# Altium schematic sheet DC_BUS.SchDoc
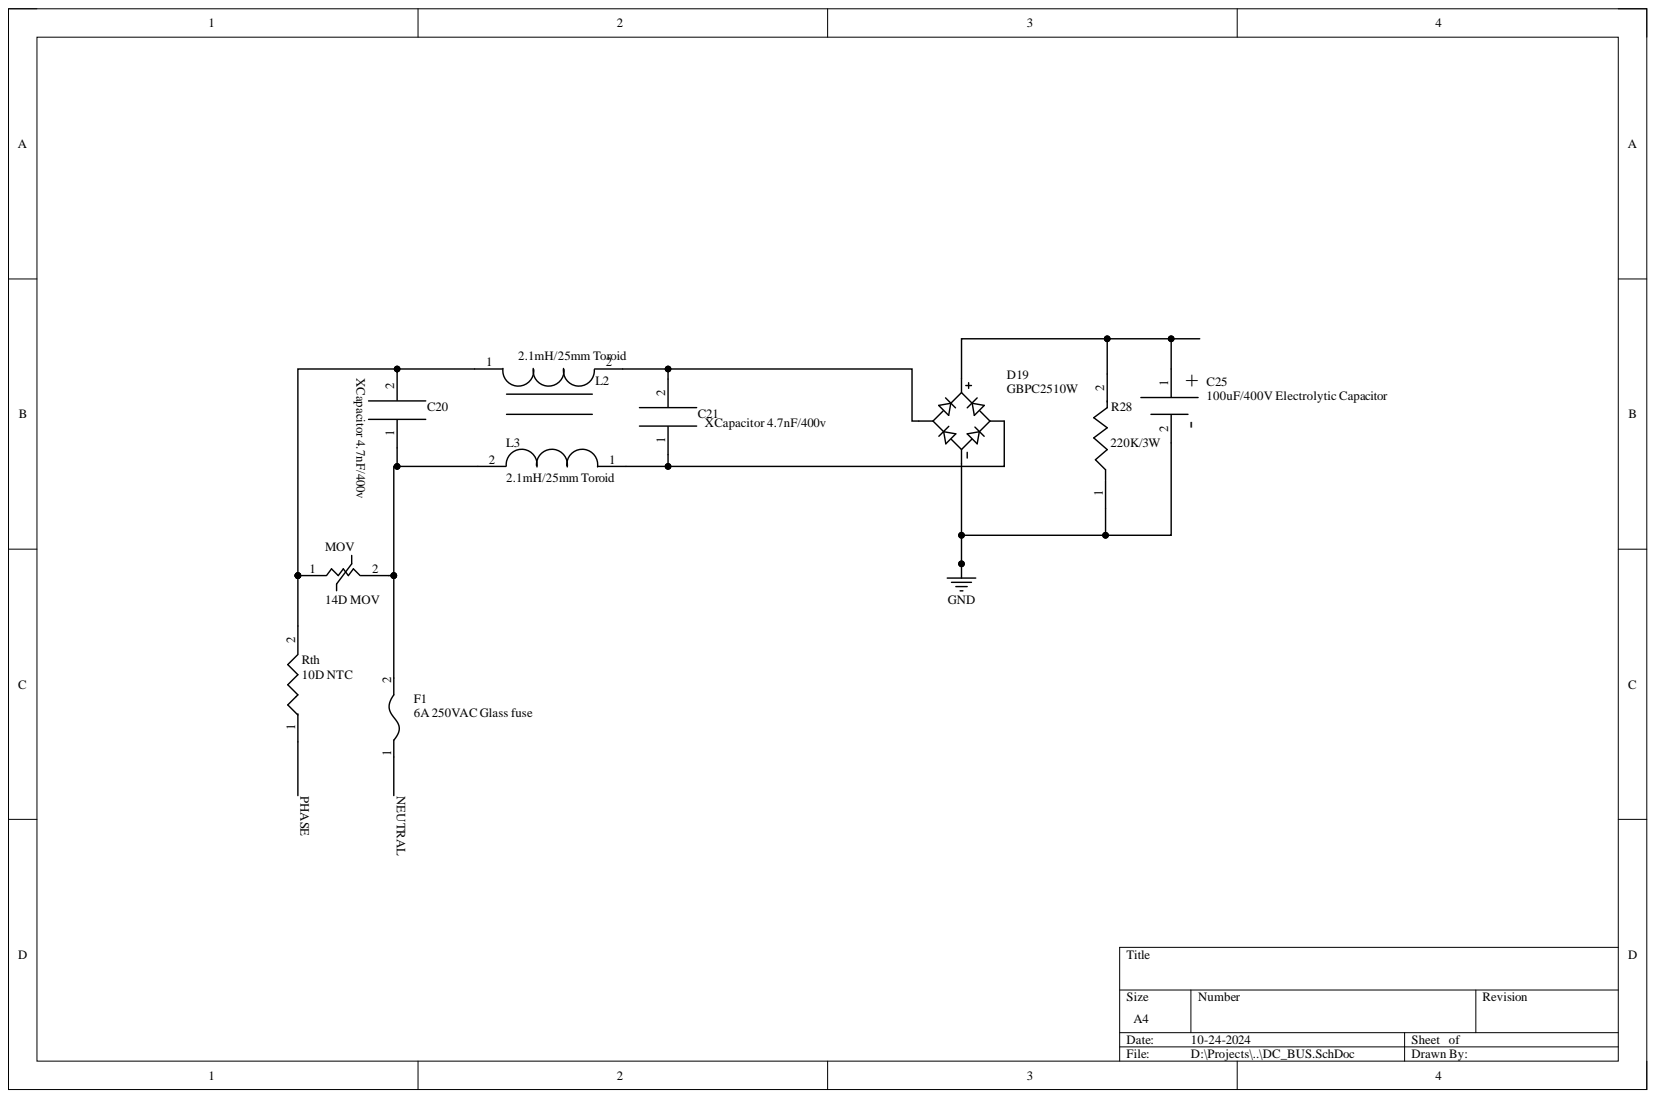
[diagram p0: DC_BUS.SchDoc]

## Parts
C20  XCapacitor 4.7nF/400v  [X Cpacitor 1-100nF/400V]
C21  XCapacitor 4.7nF/400v  [X Cpacitor 1-100nF/400V]
C25  100uF/400V Electrolytic Capacitor  [100uF/400V Electrolytic capacitor]
D19  GBPC2510W  [DIOB_GBPC2510W]
F1  6A 250VAC Glass fuse  [Glassfuse box]
L2  2.1mH/25mm Toroid  [25mm toroid]
L3  2.1mH/25mm Toroid  [25mm toroid]
MOV  14D MOV  [14D MOV]
R28  220K/3W  [1W Resistor]
Rth  10D NTC  [5mm pitch 4mm thick component]

## Nets
GND: C25.2 D19.NEG R28.1
NEUTRAL: F1.1
PHASE: Rth.1
NetC20_1: C20.1 F1.2 L3.2 MOV.2
NetC20_2: C20.2 L2.1 MOV.1 Rth.2
NetC21_1: C21.1 D19.AC1 L3.1
NetC21_2: C21.2 D19.AC2 L2.2
NetC25_1: C25.1 D19.POS R28.2

## Library data of the parts
C20 C21 = XCapacitor 1-100nF/400v: Component Kind: Standard; Library Name: Custom1.IntLib
D19 = GBPC2510W: 25A, 1000V, Standard Bridge Rectifier; MF: Taiwan Semiconductor; Availability: In Stock; Check_prices: https://www.snapeda.com/parts/GBPC2510W/Taiwan+Semiconductor/view-part/?ref=eda; Component Kind: Standard; Library Name: GBPC2510W.IntLib; MP: GBPC2510W; Package: GBPC-W Taiwan Semiconductor; Price: None; SnapEDA_Link: https://www.snapeda.com/parts/GBPC2510W/Taiwan+Semiconductor/view-part/?ref=snap
F1 = Glass fuse: Component Kind: Standard; Library Name: Custom1.IntLib
L2 L3 = 25mm Toroid: Component Kind: Standard; Library Name: Custom1.IntLib
R28 = 1W carbon Resistor: Component Kind: Standard; Library Name: Custom1.IntLib
Rth = NTC 10D: Component Kind: Standard; Library Name: Custom1.IntLib
C25 = 100uF/400V Electrolytic Capacitor: Component Kind: Standard; Library Name: Custom1.IntLib
MOV = 14D MOV: Component Kind: Standard; Library Name: Custom1.IntLib
